# Altium schematic sheet CH579M.SchDoc
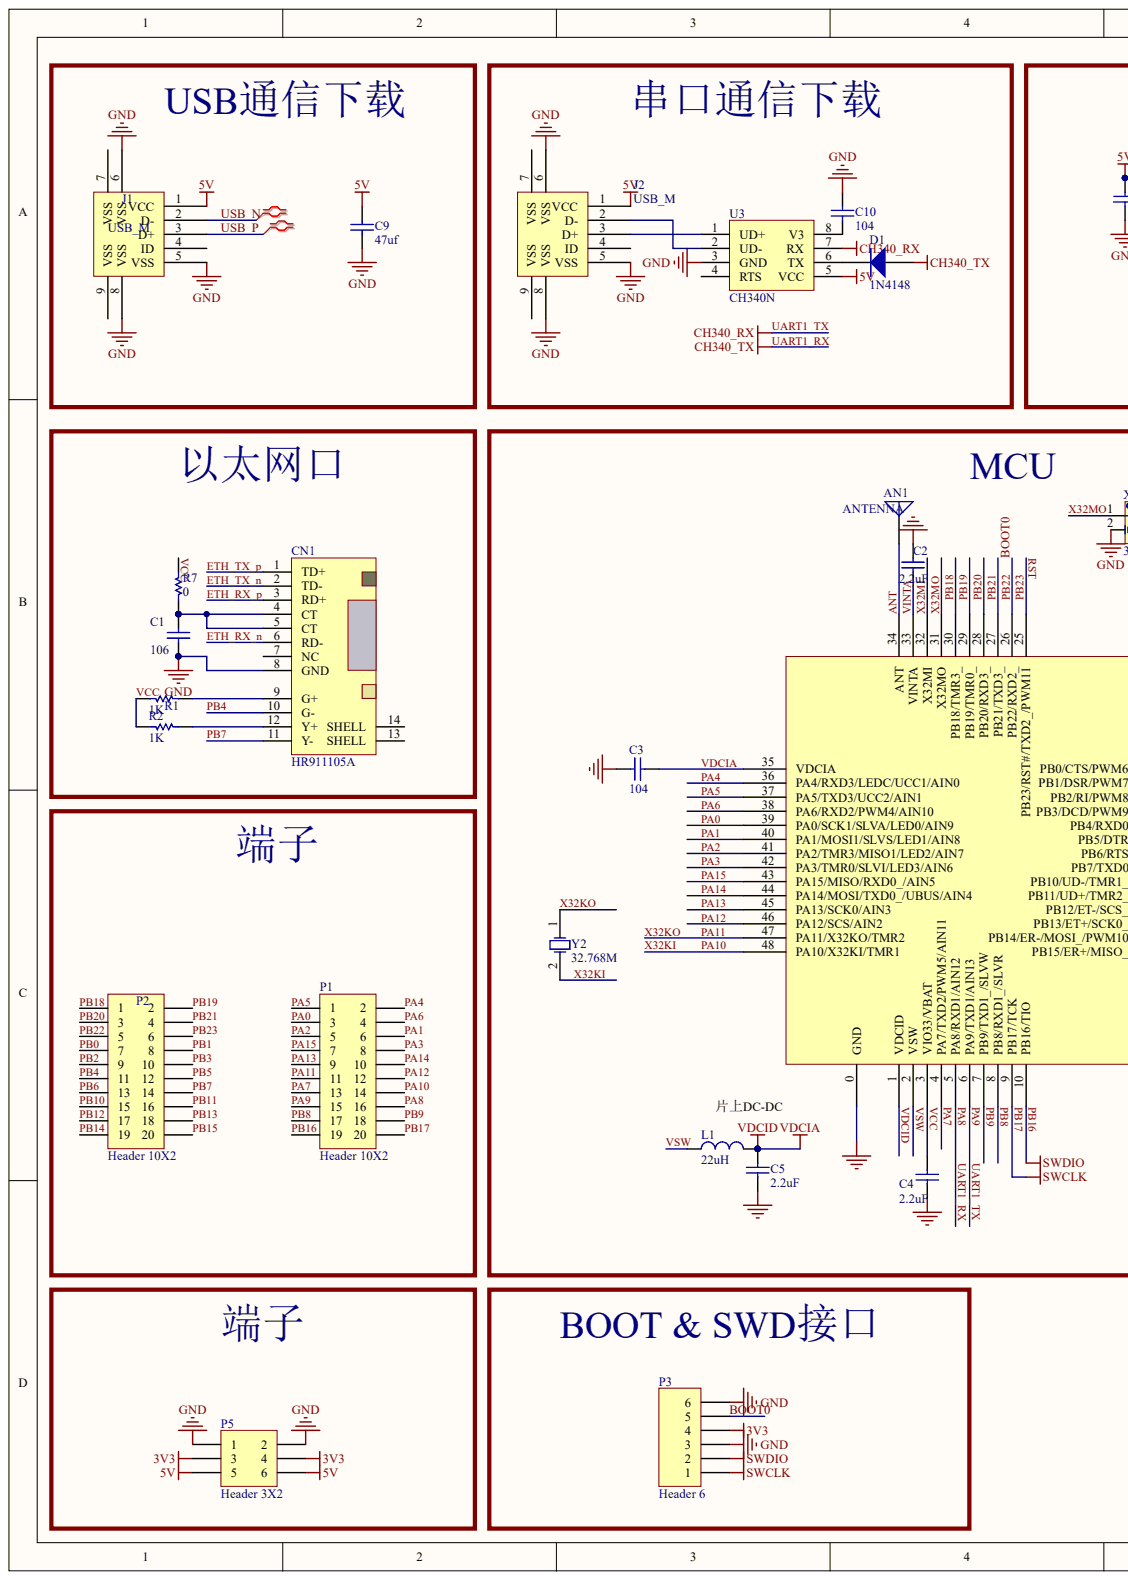
[diagram p0: CH579M.SchDoc, left]
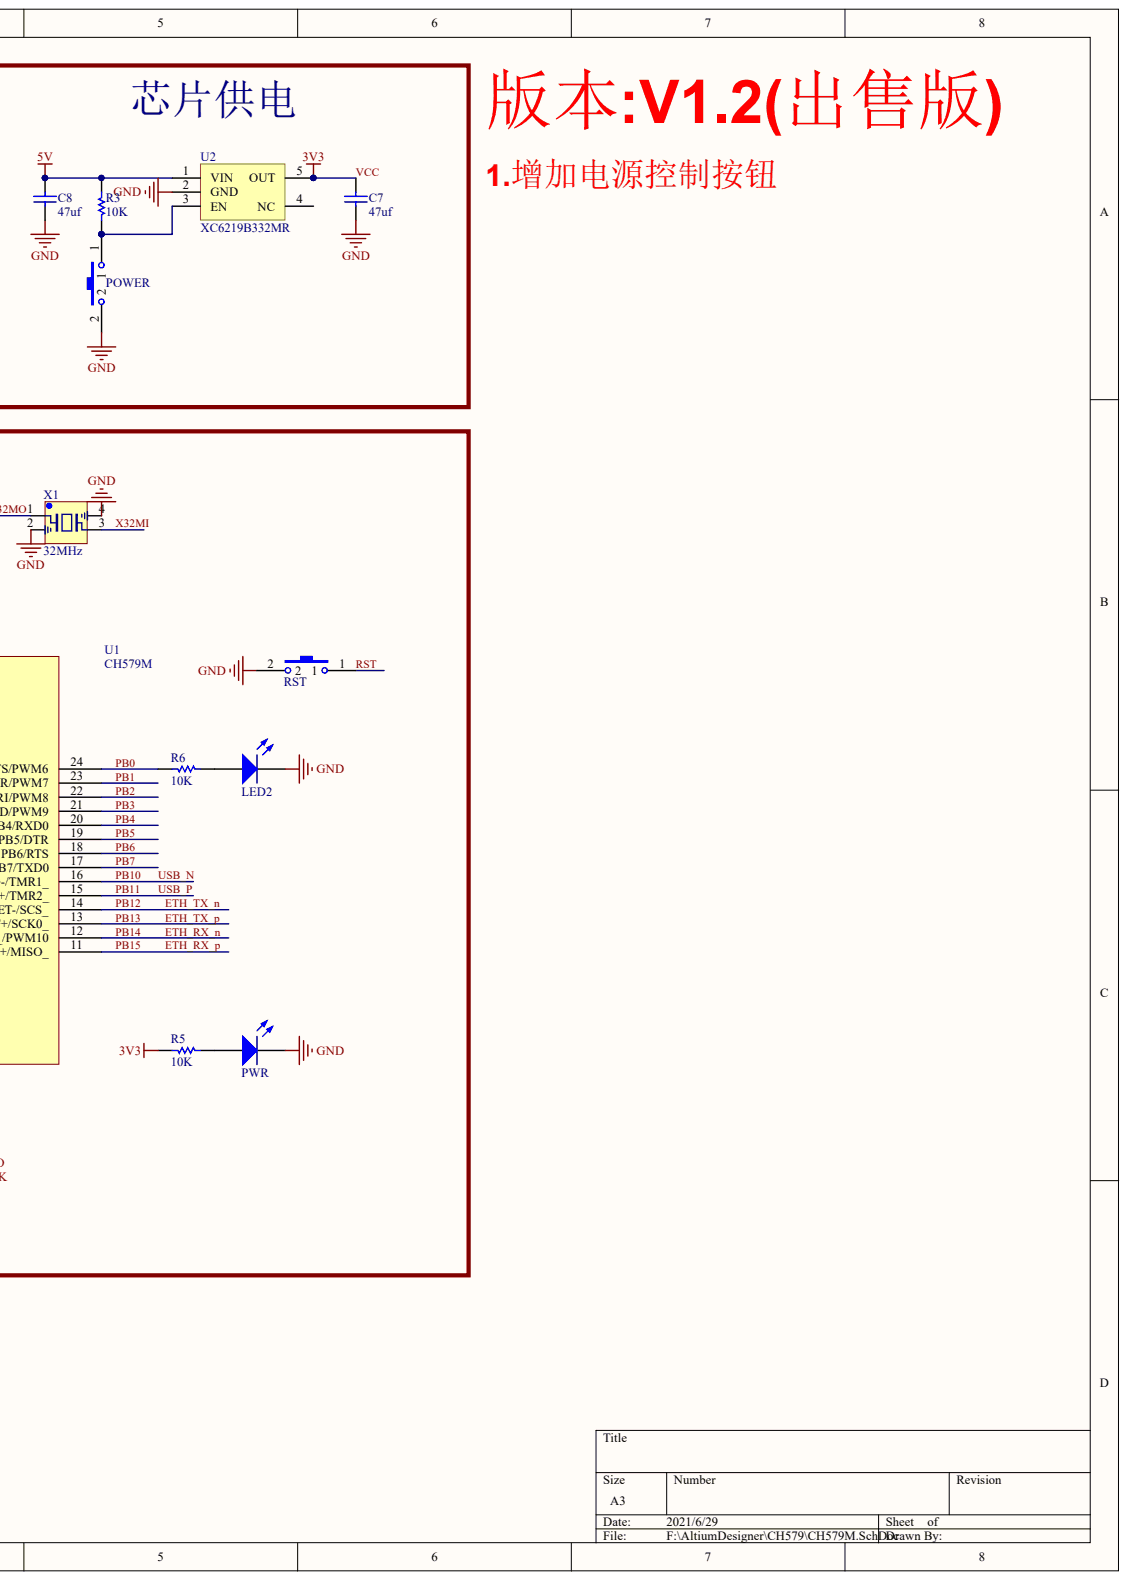
[diagram p1: CH579M.SchDoc, right]

## Parts
AN1  ANTENNA
C1  106
C2  2.2uF
C3  104
C4  2.2uF
C5  2.2uF
C7  47uf
C8  47uf
C9  47uf
C10  104
CN1  HR911105A
D1  1N4148
J1  USB_M
J2  USB_M
L1  value 22uH  (hidden comment: Inductor)
LED2  LED 0603 RED  (value not drawn)
P1  Header 10X2
P2  Header 10X2
P3  Header 6
P5  Header 3X2
POWER  SW-PB  (value not drawn)
PWR  LED 0603 RED  (value not drawn)
R1  1K
R2  1K
R3  10K
R5  10K
R6  10K
R7  0
RST  SW-PB  (value not drawn)
U1  CH579M
U2  XC6219B332MR
U3  CH340N
X1  32MHz
Y2  32.768M

## Nets
5V: C8.2 C9.2 J1.1(VCC) J2.1(VCC) P5.5 P5.6 R3.2 U2.1(VIN) U3.5(VCC)
GND: C1.1 C2.2 C3.2 C4.2 C5.2 C7.1 C8.1 C9.1 C10.2 CN1.8(GND) J1.5(VSS) J1.6(VSS) J1.8(VSS) J2.5(VSS) J2.6(VSS) J2.8(VSS) LED2.2 P3.3 P3.6 P5.1 P5.2 POWER.2 PWR.2 RST.2 U1.0(GND) U2.2(GND) U3.3(GND) X1.2 X1.4
ANT: AN1.1 U1.34(ANT)
BOOT0: P2.5 P3.5 U1.26(PB22/RXD2_)
ETH_RX_N: CN1.6(RD-) P2.19 U1.12(PB14/ER-/MOSI_/PWM10)
ETH_RX_P: CN1.3(RD+) P2.20 U1.11(PB15/ER+/MISO_)
ETH_TX_N: CN1.2(TD-) P2.17 U1.14(PB12/ET-/SCS_)
ETH_TX_P: CN1.1(TD+) P2.18 U1.13(PB13/ET+/SCK0_)
PA0: P1.3 U1.39(PA0/SCK1/SLVA/LED0/AIN9)
PA1: P1.6 U1.40(PA1/MOSI1/SLVS/LED1/AIN8)
PA2: P1.5 U1.41(PA2/TMR3/MISO1/LED2/AIN7)
PA3: P1.8 U1.42(PA3/TMR0/SLVI/LED3/AIN6)
PA4: P1.2 U1.36(PA4/RXD3/LEDC/UCC1/AIN0)
PA5: P1.1 U1.37(PA5/TXD3/UCC2/AIN1)
PA6: P1.4 U1.38(PA6/RXD2/PWM4/AIN10)
PA7: P1.13 U1.4(PA7/TXD2/PWM5/AIN11)
PA8: D1.1 P1.16 U1.5(PA8/RXD1/AIN12)
PA9: P1.15 U1.6(PA9/TXD1/AIN13) U3.7(RX)
PA10: P1.14 U1.48(PA10/X32KI/TMR1) Y2.2
PA11: P1.11 U1.47(PA11/X32KO/TMR2) Y2.1
PA12: P1.12 U1.46(PA12/SCS/AIN2)
PA13: P1.9 U1.45(PA13/SCK0/AIN3)
PA14: P1.10 U1.44(PA14/MOSI/TXD0_/UBUS/AIN4)
PA15: P1.7 U1.43(PA15/MISO/RXD0_/AIN5)
PB0: P2.7 R6.1 U1.24(PB0/CTS/PWM6)
PB1: P2.8 U1.23(PB1/DSR/PWM7)
PB2: P2.9 U1.22(PB2/RI/PWM8)
PB3: P2.10 U1.21(PB3/DCD/PWM9)
PB4: CN1.10(G-) P2.11 U1.20(PB4/RXD0)
PB5: P2.12 U1.19(PB5/DTR)
PB6: P2.13 U1.18(PB6/RTS)
PB7: CN1.11(Y-) P2.14 U1.17(PB7/TXD0)
PB8: P1.17 U1.8(PB8/RXD1_/SLVR)
PB9: P1.18 U1.7(PB9/TXD1_/SLVW)
PB10: J1.2(D-) P2.15 U1.16(PB10/UD-/TMR1_)
PB11: J1.3(D+) P2.16 U1.15(PB11/UD+/TMR2_)
PB16: P1.19 P3.2 U1.10(PB16/TIO)
PB17: P1.20 P3.1 U1.9(PB17/TCK)
PB18: P2.1 U1.30(PB18/TMR3_)
PB19: P2.2 U1.29(PB19/TMR0_)
PB20: P2.3 U1.28(PB20/RXD3_)
PB21: P2.4 U1.27(PB21/TXD3_)
PB23: P2.6 RST.1 U1.25(PB23/RST#/TXD2_/PWM11)
VCC: C4.1 C7.2 P3.4 P5.3 P5.4 R1.1 R2.1 R5.1 R7.2 U1.3(VIO33/VBAT) U2.5(OUT)
VDCIA: C3.1 C5.1 L1.2 U1.1(VDCID) U1.35(VDCIA)
VINTA: C2.1 U1.33(VINTA)
VSW: L1.1 U1.2(VSW)
X32MI: U1.32(X32MI) X1.3
X32MO: U1.31(X32MO) X1.1
NetC1_2: C1.2 CN1.4(CT) CN1.5(CT) R7.1
NetC10_1: C10.1 U3.8(V3)
NetCN1_9: CN1.9(G+) R1.2
NetCN1_12: CN1.12(Y+) R2.2
NetD1_2: D1.2 U3.6(TX)
NetJ2_2: J2.2(D-) U3.2(UD-)
NetJ2_3: J2.3(D+) U3.1(UD+)
NetLED2_1: LED2.1 R6.2
NetPOWER_1: POWER.1 R3.1 U2.3(EN)
NetPWR_1: PWR.1 R5.2

Not connected: CN1 7(NC) 13(SHELL) 14(SHELL); J1 4(ID) 7(VSS) 9(VSS); J2 4(ID) 7(VSS) 9(VSS); U2 4(NC); U3 4(RTS)

## Library data of the parts
C1-C5 C10 = (no symbol name): Value: 22pF; Published: 8-Jun-2000; LatestRevisionDate: 17-Jul-2002; LatestRevisionNote: Re-released for DXP Platform.; PackageReference: RAD-0.3; PackageDescription: Radial Cap, Thru-Hole; 2 Leads; 0.3 in Pin Spacing; Publisher: Altium Limited
L1 = (no symbol name): Code_IEC: 1005; Published: 8-Jun-2000; LatestRevisionDate: 17-Jul-2002; LatestRevisionNote: Re-released for DXP Platform.; PackageReference: 0402-A; Code_JEITA: 0402; Publisher: Altium Limited
R1 R2 R7 = (no symbol name): Value: 10K; Published: 8-Jun-2000; LatestRevisionDate: 17-Jul-2002; LatestRevisionNote: Re-released for DXP Platform.; PackageReference: AXIAL-0.3; PackageDescription: Axial Device, Thru-Hole; 2 Leads; 0.3 in Pin Spacing; Publisher: Altium Limited
AN1 C7-C9 CN1 D1 J1 J2 LED2 P1-P3 P5 POWER PWR R3 R5 R6 RST U1-U3 X1 Y2 = (no symbol name)
  C7-C9: Published: 8-Jun-2000; LatestRevisionDate: 17-Jul-2002; LatestRevisionNote: Re-released for DXP Platform.; PackageReference: RAD-0.3; PackageDescription: Radial Cap, Thru-Hole; 2 Leads; 0.3 in Pin Spacing
  D1: Published: 8-Jun-2000; LatestRevisionDate: 17-Jul-2002; LatestRevisionNote: Re-released for DXP Platform.; PackageReference: SMC; PackageDescription: DO-214-AB/SMC; 2 C-Bend Leads; Body 7.9 x 5.9 mm, inc. leads (LxW); PackageVersion: Sep-1996; Code_JEDEC: DO-214-AB
  LED2 PWR: Published: 8-Jun-2000; LatestRevisionDate: 17-Jul-2002; LatestRevisionNote: Re-released for DXP Platform.; PackageVersion: Feb-2002; PackageReference: 3.2X1.6X1.1; PackageDescription: SM LED; 2 Flat Leads
  P1-P3 P5: LatestRevisionDate: 17-Jul-2002; LatestRevisionNote: Re-released for DXP Platform.
  POWER RST: Published: 24-Mar-1999; LatestRevisionDate: 17-Jul-2002; LatestRevisionNote: Re-released for DXP Platform.; PackageReference: SPST-2; PackageDescription: Switch; 2 Leads
  R3 R5 R6: Published: 8-Jun-2000; LatestRevisionDate: 17-Jul-2002; LatestRevisionNote: Re-released for DXP Platform.; PackageReference: AXIAL-0.3; PackageDescription: Axial Device, Thru-Hole; 2 Leads; 0.3 in Pin Spacing; Value: 10K
  Y2: Published: 8-Jun-2000; LatestRevisionDate: 17-Jul-2002; LatestRevisionNote: Re-released for DXP Platform.; PackageReference: R38; PackageDescription: Crystal, Thru-Hole; 2 Leads; Body 3.1 x 8.2 mm (Dia.xH)
  C7-C9 D1 LED2 P1-P3 P5 POWER PWR R3 R5 R6 RST Y2: Publisher: Altium Limited
